# Altium schematic sheet PC104.SchDoc
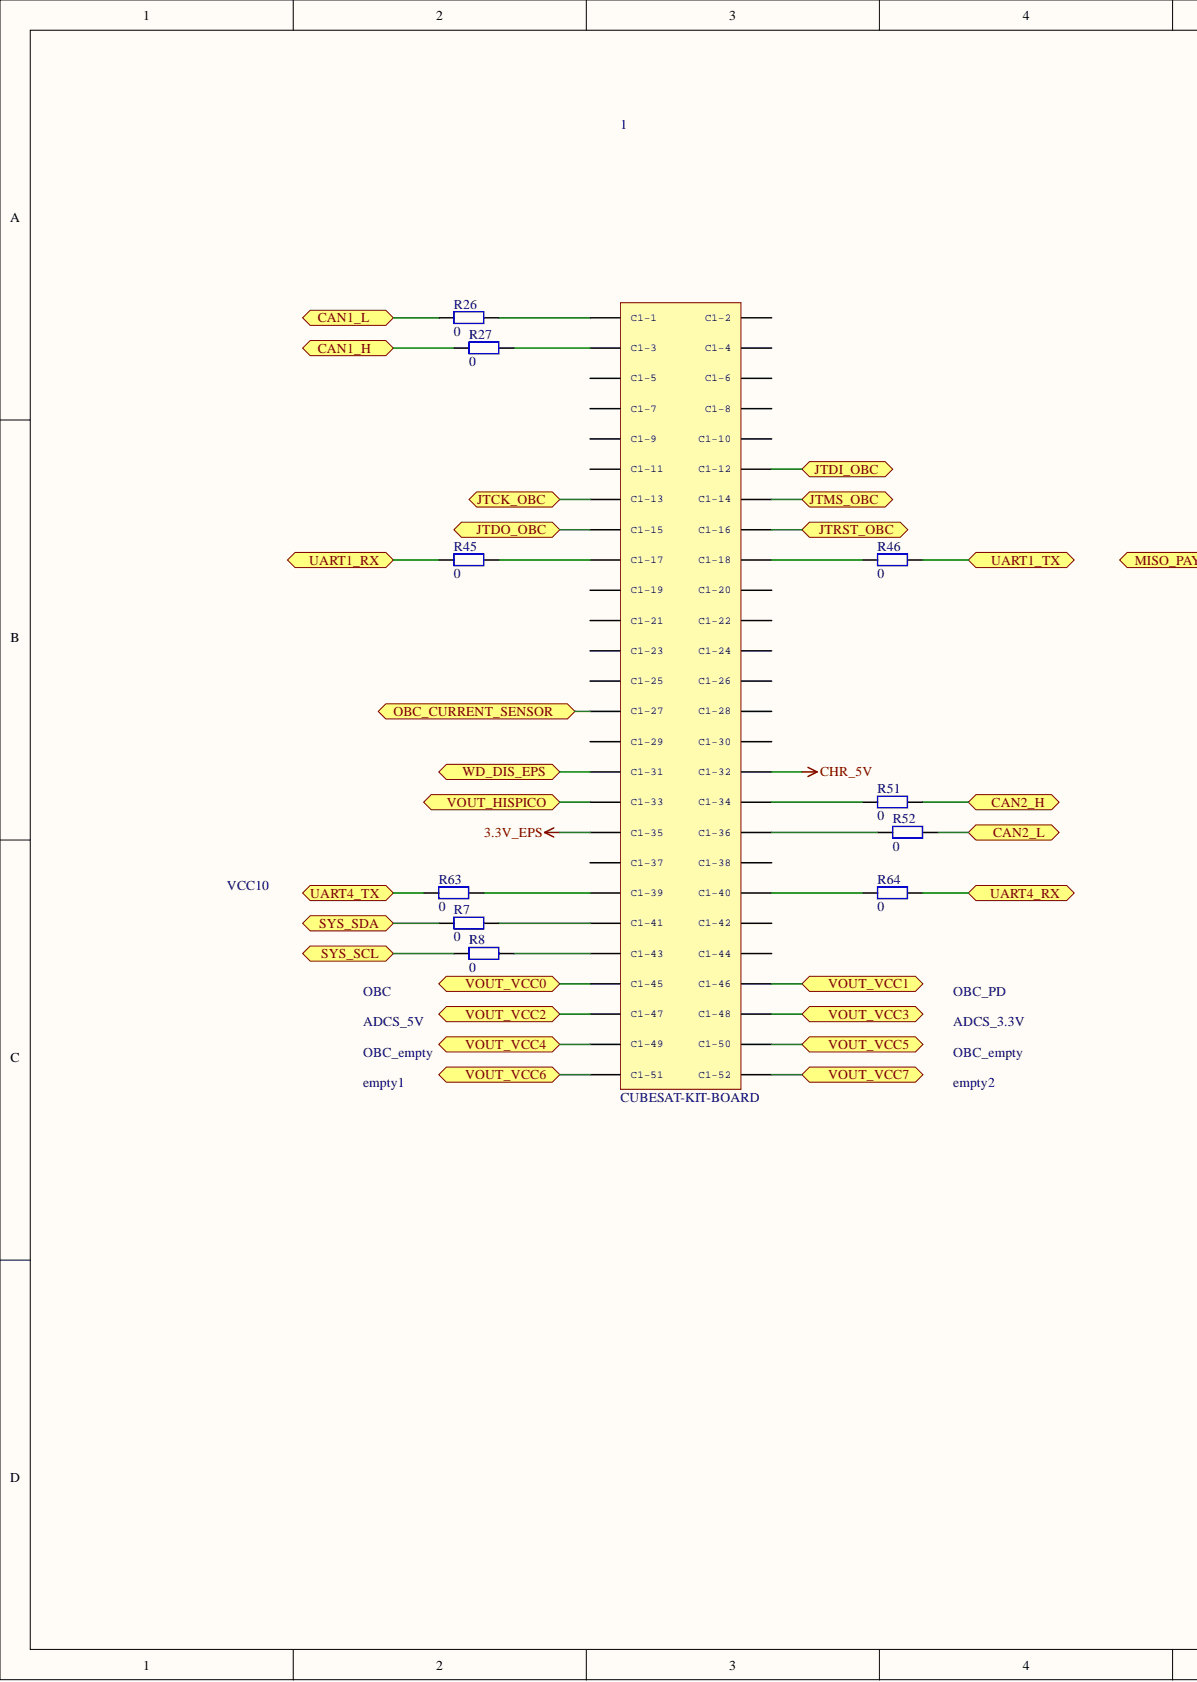
[diagram p0: PC104.SchDoc, left]
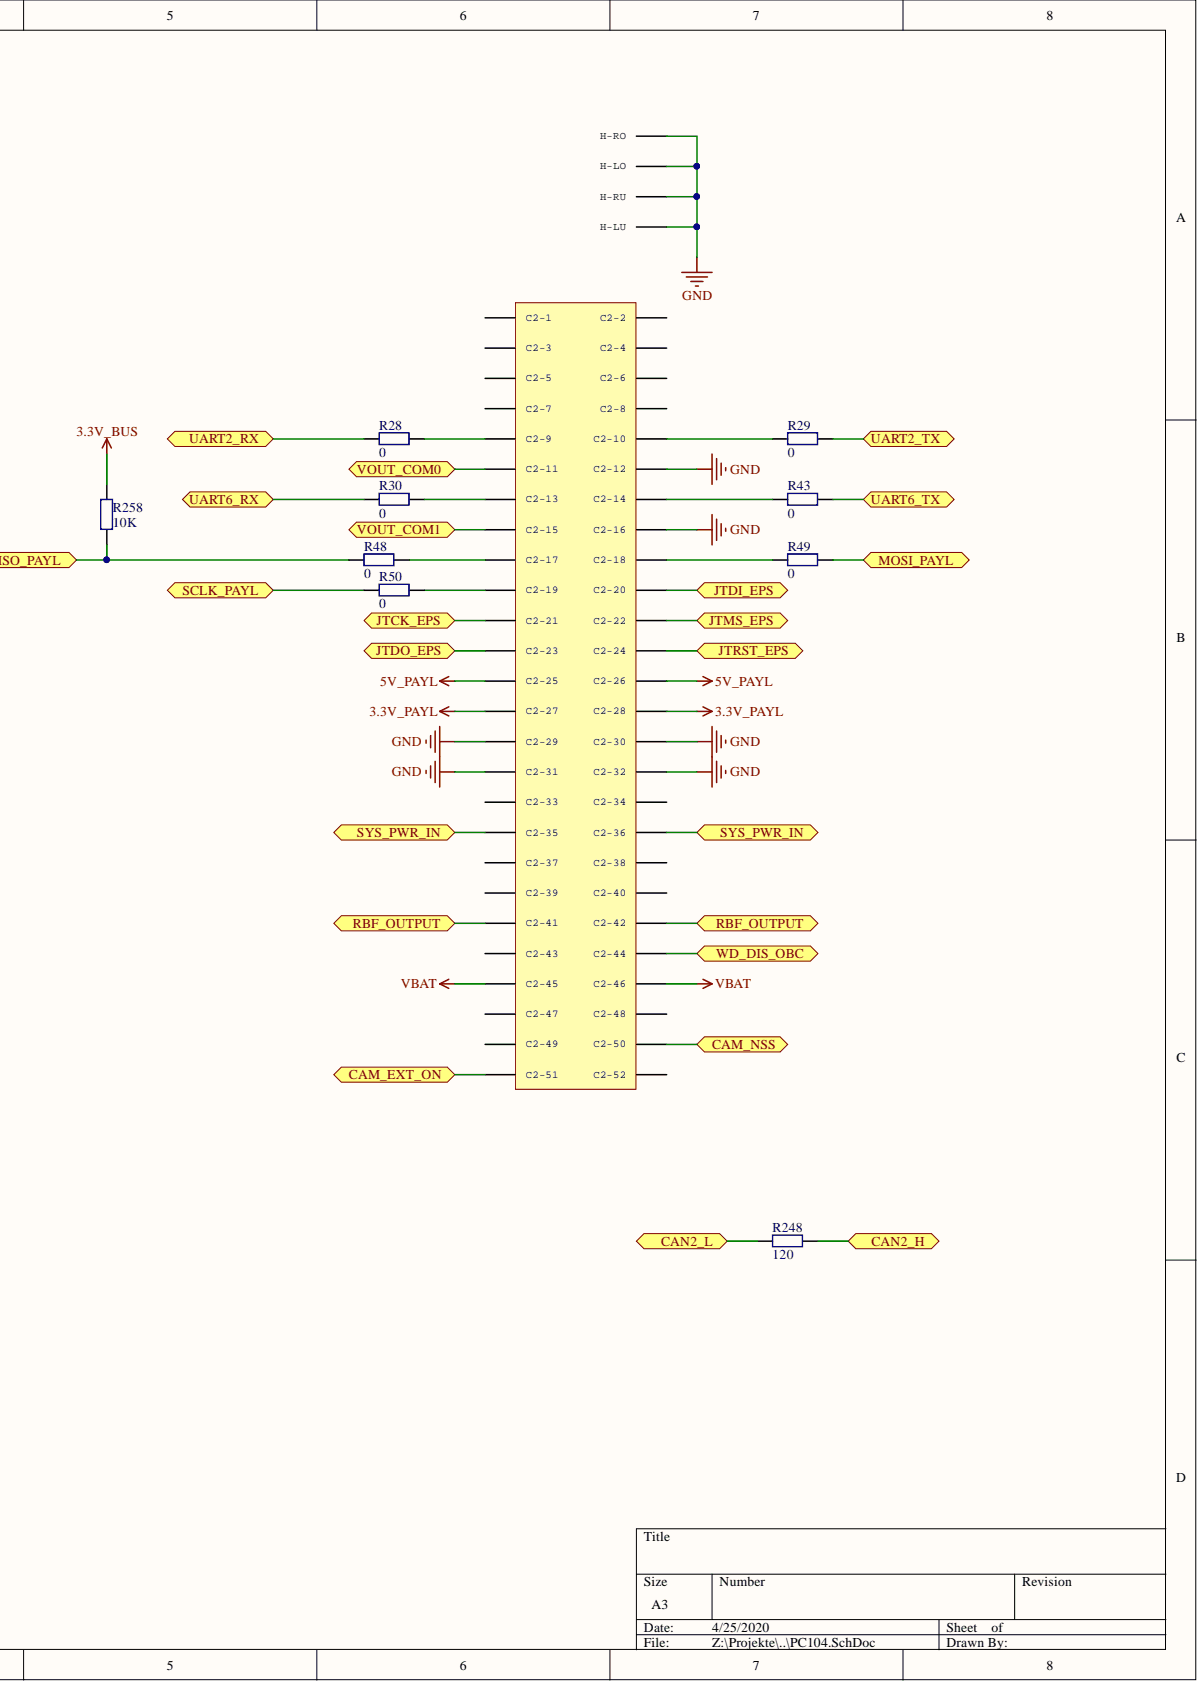
[diagram p1: PC104.SchDoc, right]

## Parts
1  CUBESAT-KIT-BOARD  [PC104-CSK]
R7  0  [1608[0603]]
R8  0  [1608[0603]]
R26  0  [1608[0603]]
R27  0  [1608[0603]]
R28  0  [1608[0603]]
R29  0  [1608[0603]]
R30  0  [1608[0603]]
R43  0  [1608[0603]]
R45  0  [1608[0603]]
R46  0  [1608[0603]]
R48  0  [1608[0603]]
R49  0  [1608[0603]]
R50  0  [1608[0603]]
R51  0  [1608[0603]]
R52  0  [1608[0603]]
R63  0  [1608[0603]]
R64  0  [1608[0603]]
R248  value 120  [1608[0603]]  (hidden comment: 120 n.p.)
R258  10K  [1608[0603]]

## Ports
CAM_EXT_ON, CAM_NSS, CAN1_H, CAN1_L, CAN2_H, CAN2_L, JTCK_EPS, JTCK_OBC, JTDI_EPS, JTDI_OBC, JTDO_EPS, JTDO_OBC, JTMS_EPS, JTMS_OBC, JTRST_EPS, JTRST_OBC, MISO_PAYL, MOSI_PAYL, OBC_CURRENT_SENSOR, RBF_OUTPUT, SCLK_PAYL, SYS_PWR_IN, SYS_SCL, SYS_SDA, UART1_RX, UART1_TX, UART2_RX, UART2_TX, UART4_RX, UART4_TX, UART6_RX, UART6_TX, VOUT_COM0, VOUT_COM1, VOUT_HISPICO, VOUT_VCC0, VOUT_VCC1, VOUT_VCC2, VOUT_VCC3, VOUT_VCC4, VOUT_VCC5, VOUT_VCC6, VOUT_VCC7, WD_DIS_EPS, WD_DIS_OBC

## Nets
3.3V_BUS: R258.2
3.3V_EPS: 1.C1-35
3.3V_PAYL: 1.C2-27 1.C2-28
5V_PAYL: 1.C2-25 1.C2-26
CHR_5V: 1.C1-32
GND: 1.C2-12 1.C2-16 1.C2-29 1.C2-30 1.C2-31 1.C2-32 1.H_LO(H-LO) 1.H_LU(H-LU) 1.H_RO(H-RO) 1.H_RU(H-RU)
VBAT: 1.C2-45 1.C2-46
CAM_EXT_ON: 1.C2-51 ; port CAM_EXT_ON
CAM_NSS: 1.C2-50 ; port CAM_NSS
CAN1_H: R27.2 ; port CAN1_H
CAN1_L: R26.2 ; port CAN1_L
CAN2_H: R51.1 R248.2 ; port CAN2_H
CAN2_L: R52.1 R248.1 ; port CAN2_L
JTCK_EPS: 1.C2-21 ; port JTCK_EPS
JTCK_OBC: 1.C1-13 ; port JTCK_OBC
JTDI_EPS: 1.C2-20 ; port JTDI_EPS
JTDI_OBC: 1.C1-12 ; port JTDI_OBC
JTDO_EPS: 1.C2-23 ; port JTDO_EPS
JTDO_OBC: 1.C1-15 ; port JTDO_OBC
JTMS_EPS: 1.C2-22 ; port JTMS_EPS
JTMS_OBC: 1.C1-14 ; port JTMS_OBC
JTRST_EPS: 1.C2-24 ; port JTRST_EPS
JTRST_OBC: 1.C1-16 ; port JTRST_OBC
MISO_PAYL: R48.2 R258.1 ; port MISO_PAYL
MOSI_PAYL: R49.1 ; port MOSI_PAYL
OBC_CURRENT_SENSOR: 1.C1-27 ; port OBC_CURRENT_SENSOR
RBF_OUTPUT: 1.C2-41 1.C2-42 ; port RBF_OUTPUT
SCLK_PAYL: R50.2 ; port SCLK_PAYL
SYS_PWR_IN: 1.C2-35 1.C2-36 ; port SYS_PWR_IN
SYS_SCL: R8.2 ; port SYS_SCL
SYS_SDA: R7.2 ; port SYS_SDA
UART1_RX: R45.2 ; port UART1_RX
UART1_TX: R46.1 ; port UART1_TX
UART2_RX: R28.2 ; port UART2_RX
UART2_TX: R29.1 ; port UART2_TX
UART4_RX: R64.1 ; port UART4_RX
UART4_TX: R63.2 ; port UART4_TX
UART6_RX: R30.2 ; port UART6_RX
UART6_TX: R43.1 ; port UART6_TX
VOUT_COM0: 1.C2-11 ; port VOUT_COM0
VOUT_COM1: 1.C2-15 ; port VOUT_COM1
VOUT_HISPICO: 1.C1-33 ; port VOUT_HISPICO
VOUT_VCC0: 1.C1-45 ; port VOUT_VCC0
VOUT_VCC1: 1.C1-46 ; port VOUT_VCC1
VOUT_VCC2: 1.C1-47 ; port VOUT_VCC2
VOUT_VCC3: 1.C1-48 ; port VOUT_VCC3
VOUT_VCC4: 1.C1-49 ; port VOUT_VCC4
VOUT_VCC5: 1.C1-50 ; port VOUT_VCC5
VOUT_VCC6: 1.C1-51 ; port VOUT_VCC6
VOUT_VCC7: 1.C1-52 ; port VOUT_VCC7
WD_DIS_EPS: 1.C1-31 ; port WD_DIS_EPS
WD_DIS_OBC: 1.C2-44 ; port WD_DIS_OBC
Net1_C1-1: 1.C1-1 R26.1
Net1_C1-3: 1.C1-3 R27.1
Net1_C1-17: 1.C1-17 R45.1
Net1_C1-18: 1.C1-18 R46.2
Net1_C1-34: 1.C1-34 R51.2
Net1_C1-36: 1.C1-36 R52.2
Net1_C1-39: 1.C1-39 R63.1
Net1_C1-40: 1.C1-40 R64.2
Net1_C1-41: 1.C1-41 R7.1
Net1_C1-43: 1.C1-43 R8.1
Net1_C2-9: 1.C2-9 R28.1
Net1_C2-10: 1.C2-10 R29.2
Net1_C2-13: 1.C2-13 R30.1
Net1_C2-14: 1.C2-14 R43.2
Net1_C2-17: 1.C2-17 R48.1
Net1_C2-18: 1.C2-18 R49.2
Net1_C2-19: 1.C2-19 R50.1

Not connected: 1 C1-2 C1-4 C1-5 C1-6 C1-7 C1-8 C1-9 C1-10 C1-11 C1-19 C1-20 C1-21 C1-22 C1-23 C1-24 C1-25 C1-26 C1-28 C1-29 C1-30 C1-37 C1-38 C1-42 C1-44 C2-1 C2-2 C2-3 C2-4 C2-5 C2-6 C2-7 C2-8 C2-33 C2-34 C2-37 C2-38 C2-39 C2-40 C2-43 C2-47 C2-48 C2-49 C2-52

## Library data of the parts
R7 R8 R26-R30 R43 R45 R46 R48-R52 R63 R64 R248 R258 = Res2: Resistor; Component Kind: Standard; LatestRevisionDate: 17-Jul-2002; LatestRevisionNote: Re-released for DXP Platform.; Library Name: Miscellaneous Devices.IntLib; PackageDescription: Axial Device, Thru-Hole; 2 Leads; 0.4 in Pin Spacing; PackageReference: AXIAL-0.4; Published: 8-Jun-2000; Signal Integrity: Res; Simulation: RESISTOR; Publisher: Altium Limited
  R7 R8 R26-R30 R43 R45 R46 R48-R52 R63 R64: Value: 0
  R258: Value: 10K
1 = CUBESAT-KIT-BOARD: Component Kind: Standard; Library Name: CubesatKitBib_pavel.SCHLIB
